# Altium schematic sheet interfaces_module.SchDoc
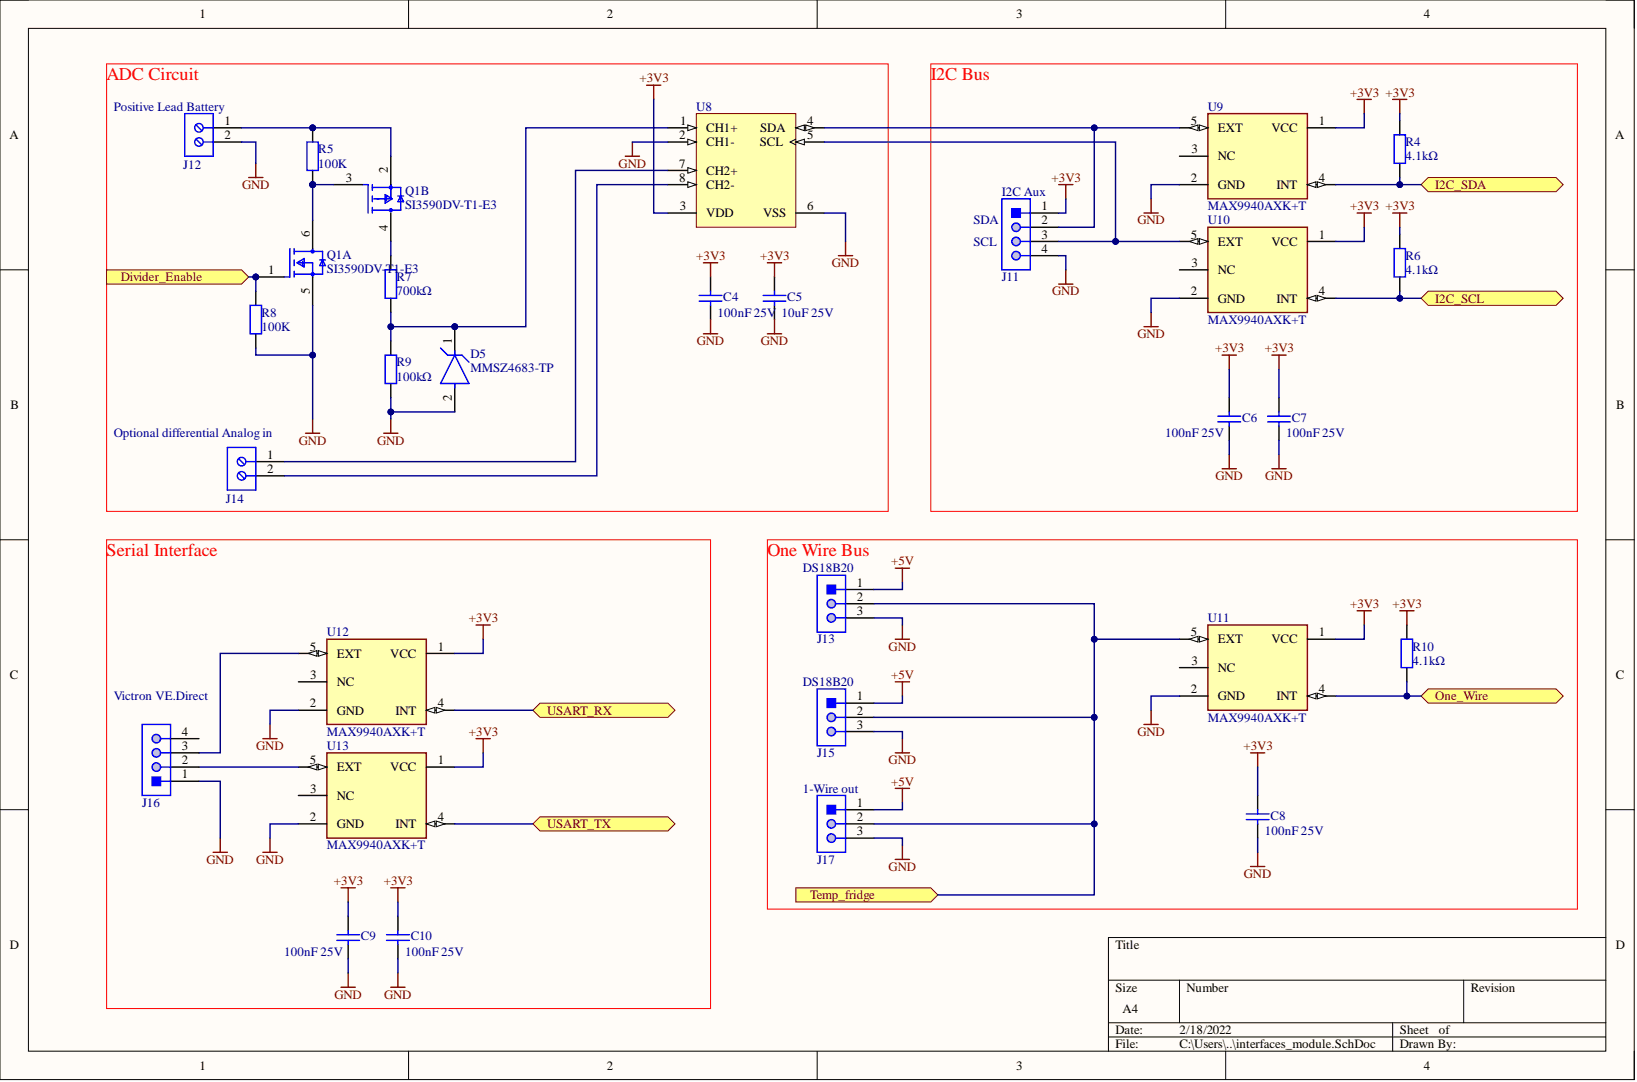
[diagram p0: interfaces_module.SchDoc]

## Parts
C4  100nF 25V  [CAPC2013X88X50ML20T25]
C5  10uF 25V  [CAPC2013X88X50ML20T25]
C6  100nF 25V  [CAPC2013X88X50ML20T25]
C7  100nF 25V  [CAPC2013X88X50ML20T25]
C8  100nF 25V  [CAPC2013X88X50ML20T25]
C9  100nF 25V  [CAPC2013X88X50ML20T25]
C10  100nF 25V  [CAPC2013X88X50ML20T25]
D5  MMSZ4683-TP  [FP-SOD-123-MFG]
J11  JST-S4B-PH-SM4-TB-3_V  [JST-S4B-PH-SM4-TB-3_V]  (value not drawn)
J12  282836-2  [TECO-282836-2_V]  (value not drawn)
J13  JST-S3B-PH-SM4-TB-3_V  [JST-S3B-PH-SM4-TB-3_V]  (value not drawn)
J14  282836-2  [TECO-282836-2_V]  (value not drawn)
J15  JST-S3B-PH-SM4-TB-3_V  [JST-S3B-PH-SM4-TB-3_V]  (value not drawn)
J16  JST-S4B-PH-SM4-TB-3_V  [JST-S4B-PH-SM4-TB-3_V]  (value not drawn)
J17  JST-S3B-PH-SM4-TB-3_V  [JST-S3B-PH-SM4-TB-3_V]  (value not drawn)
Q1  SI3590DV-T1-E3  [FP-C-06593-6-MFG]  (parts A,B)
R4  4.1kΩ  [RESC2013X50X30ML20T20]
R5  100K  [RESC2013X50X30ML20T20]
R6  4.1kΩ  [RESC2013X50X30ML20T20]
R7  700kΩ  [RESC2013X50X30ML20T20]
R8  100K  [RESC2013X50X30ML20T20]
R9  100kΩ  [RESC2013X50X30ML20T20]
R10  4.1kΩ  [RESC2013X50X30ML20T20]
U8  (no value)  [SOIC-SN8_L]
U9  MAX9940AXK+T  [FP-X5-1-IPC_C]
U10  MAX9940AXK+T  [FP-X5-1-IPC_C]
U11  MAX9940AXK+T  [FP-X5-1-IPC_C]
U12  MAX9940AXK+T  [FP-X5-1-IPC_C]
U13  MAX9940AXK+T  [FP-X5-1-IPC_C]

## Ports
Divider_Enable, I2C_SCL, I2C_SDA, One_Wire, Temp_fridge, USART_RX, USART_TX

## Nets
+3V3: C4.2 C5.2 C6.2 C7.2 C8.2 C9.2 C10.2 J11.1 R4.2 R6.2 R10.2 U8.3(VDD) U9.1(VCC) U10.1(VCC) U11.1(VCC) U12.1(VCC) U13.1(VCC)
+5V: J13.1 J15.1 J17.1
GND: C4.1 C5.1 C6.1 C7.1 C8.1 C9.1 C10.1 D5.2 J11.4 J12.2 J13.3 J15.3 J16.1 J17.3 Q1.5 R8.2 R9.1 U8.2(CH1-) U8.6(VSS) U9.2(GND) U10.2(GND) U11.2(GND) U12.2(GND) U13.2(GND)
Divider_Enable: Q1.1 R8.1 ; port Divider_Enable
I2C_SCL: R6.1 U10.4(INT) ; port I2C_SCL
I2C_SDA: R4.1 U9.4(INT) ; port I2C_SDA
One_Wire: R10.1 U11.4(INT) ; port One_Wire
Temp_fridge: J13.2 J15.2 J17.2 U11.5(EXT) ; port Temp_fridge
USART_RX: U12.4(INT) ; port USART_RX
USART_TX: U13.4(INT) ; port USART_TX
NetD5_1: D5.1 R7.2 R9.2 U8.1(CH1+)
NetJ11_2: J11.2 U8.4(SDA) U9.5(EXT)
NetJ11_3: J11.3 U8.5(SCL) U10.5(EXT)
NetJ12_1: J12.1 Q1.2 R5.1
NetJ14_1: J14.1 U8.7(CH2+)
NetJ14_2: J14.2 U8.8(CH2-)
NetJ16_2: J16.2 U13.5(EXT)
NetJ16_3: J16.3 U12.5(EXT)
NetQ1_3: Q1.3 Q1.6 R5.2
NetQ1_4: Q1.4 R7.1

Not connected: J16 4; U9 3(NC); U10 3(NC); U11 3(NC); U12 3(NC); U13 3(NC)

## Library data of the parts
C4-C10 = Cap_0805: Capacitor; Component Kind: Standard; Library Name: Schlib.SchLib; Signal Integrity: Cap; Simulation: CAP
D5 = CMP-56208-000004-1: DIODE ZENER 3V 500MW SOD123; Element Configuration: Single; Forward Voltage: 950mV; Max Reverse Leakage Current: 800nA; Tolerance: 5%; Component Kind: Standard; Lead Free: Lead Free; Library Name: Altium Content Vault; Max Operating Temperature: 150°C; Max Power Dissipation: 500mW; Min Operating Temperature: -55°C; Mount: Surface Mount; Number of Pins: 2; Package Quantity: 1; Packaging: Tape and Reel; Peak Reverse Current: 800nA; Power Dissipation: 500mW; Radiation Hardening: No; Voltage Tolerance: 5%; Working Voltage: 3V; Zener Voltage: 3V
J11 J16 = CONNECTOR_JST-S4B-PH-SM4: Component Kind: Standard; Description: JST-S4B-PH-SM4-TB-3_V; Library Name: integrated_library.IntLib
J12 J14 = CMP-1684-00017-1: Female Header, Pitch 5 mm, 1 x 2 Position, Height 10 mm, Tail Length 3.5 mm, Rohs, Bulk; Manufacturer: TE Connectivity; 3D Model: 282836-2.pdf; Clamp Material - Plating: Brass-NickelPlated; Current: 13.5A; Dynamic Catalog: BuchananSeries; Featured Product: EurostyleTerminalBlockConnectors; Mating Orientation: HorizontalwithBoard; Number of Levels: 1; Other Names: 5ULV-02B  A98076; Pitch: 0.197"(5.00mm); Positions Per Level: 2; Product Training Modules: Wire-to-BoardEurostyleTerminalBlocks; Screw Thread: M3; Torque - Screw: 0.68Nm(6Lb-In); Voltage: 300V; Wire Gauge: 16-30AWG; Wire Termination: Screw-RisingCageClamp; Category: Connectors,Interconnects; Color: Green; Component Kind: Standard; Contact Material - Plating: Brass-TinPlated; Datasheet URL: http://www.te.com.cn/commerce/DocumentDelivery/DDEController?Action=showdoc&DocId=Catalog+Section%7F1308389_EUROSTYLE_TERMINAL_BLOCKS%7F0607%7Fpdf%7FEnglish%7FENG_CS_1308389_EUROSTYLE_TERMINAL_BLOCKS_0607.pdf%7F282836-2; Family: TerminalBlocks-WiretoBoard; Features: Interlocking(Side); Housing Material: Polyamide(PA66),Nylon6/6; Library Name: Altium Content Vault; Manufacturer URL: http://www.te.com; Mounting Type: ThroughHole; Package Description: Female Header, Pitch 5 mm, 1 x 2 Position, Height 10 mm, Tail Length 3.5 mm; Package Reference: THD; Packaging: Bulk; RoHS Information: 282836-2StatementofCompliance; Screw Material - Plating: Brass-NickelPlated; Series: Buchanan; Standard Package: 300
J13 J15 J17 = CONNECTOR_JST-S3B-PH-SM4: Component Kind: Standard; Description: JST-S3B-PH-SM4-TB-3_V; Library Name: integrated_library.IntLib
Q1 = CMP-02550-000225-1: MOSFET N/P-CH 30V 2.5A 6TSOP; Manufacturer: Vishay; Continuous Drain Current: 2.5/-1.7A; Depletion Mode: False; Drain To Source Resistance: 0.062/0.135Ω; Drain To Source Voltage: 30/-30V; Gate To Source Voltage: 12V; Max Forward Diode Voltage: 1.1/-1.1V; Max Junction Temp: +150°C; Number Of Channels: 2; Number Of N Channels: 1; Power Dissipation: 0.83W; Pulse Drain Current: 8/-8A; Reverse Recovery Time: 15/18ns; Rthja Max: 130°C/W; Standoff Height: 0.01mm; Threshold Vgs Max: 1.5/-1.5V; Threshold Vgs Min: 0.6/-0.6V; Transconductance: 10/5S; Turn Off Delay Time: 13/20ns; Turn On Delay Time: 5/5ns; Typ Forward Diode Voltage: 0.8/-0.83V; Automotive: No; Category: Trans; Component Kind: Standard; ComponentLink2Description: Datasheet; ComponentLink2URL: https://www.vishay.com/docs/72032/72032.pdf; Device Class L1: Discrete Semiconductors; Device Class L2: Transistors; Device Class L3: MOSFETs; Digikey Description: MOSFET N/P-CH 30V 2.5A 6TSOP; Height: 1.1mm; Lead Free: Yes; Library Name: Altium Content Vault; Manufacturer Part Number: SI3590DV-T1-E3; Mouser Description: MOSFET N&P-CH 30V (D-S); Number Of P Channels: 1; Octopart: https://octopart.com/si3590dv-t1-e3-vishay-39731291; Package: C-06593-6; RoHS: TRUE; Temperature: -55°C to +150°C; Temperature Range High: +150°C; Temperature Range Low: -55°C
R4-R10 = Res_0805: Resistor; Component Kind: Standard; Library Name: PCB_Project.SchLib; Signal Integrity: Res; Simulation: RESISTOR
U8 = CMP-0188-01151-1: 16-Bit, Multi-Channel Delta-Sigma Analog-to-Digital Converter with I2C Interface and On-Board Reference, 8-Pin SOIC 150mil, Extended Temperature; Code_IPC: SOIC127P600-8; Code_JEDEC: MS-012; Component Kind: Standard; ComponentLink1Description: Manufacturer URL; ComponentLink1URL: http://www.microchip.com/; ComponentLink2Description: Datasheet; ComponentLink2URL: http://ww1.microchip.com/downloads/en/DeviceDoc/22226a.pdf; ComponentLink3Description: Package Specification; ComponentLink3URL: http://www.microchip.com/stellent/groups/techpub_sg/documents/packagingspec/en012702.pdf; DatasheetVersion: revA, Dec-2009; Library Name: Altium Content Vault; PackageDescription: 8-Lead Plastic Small Outline (SN) - Narrow, 3.90mm Body [SOIC]; PackageReference: SOIC-SN8; PackageVersion: revBB, Aug-2009
U9-U13 = CMP-05714-000005-2: IC OVERVOLTAGE PROTECTOR SC70-5; Clamping Voltage: 28V; Max Power Dissipation: 245mW; Max Supply Voltage: 5.5V; Min Supply Voltage: 2.2V; Nominal Supply Current: 13uA; Number of Circuits: 1; Component Kind: Standard; Library Name: Altium Content Vault; Max Operating Temperature: 125°C; Min Operating Temperature: -40°C; Number of Pins: 5; Package Quantity: 2500; Packaging: Tape and Reel; Radiation Hardening: No; Working Voltage: 5.5V
